# Altium schematic sheet POWER&USB.SchDoc
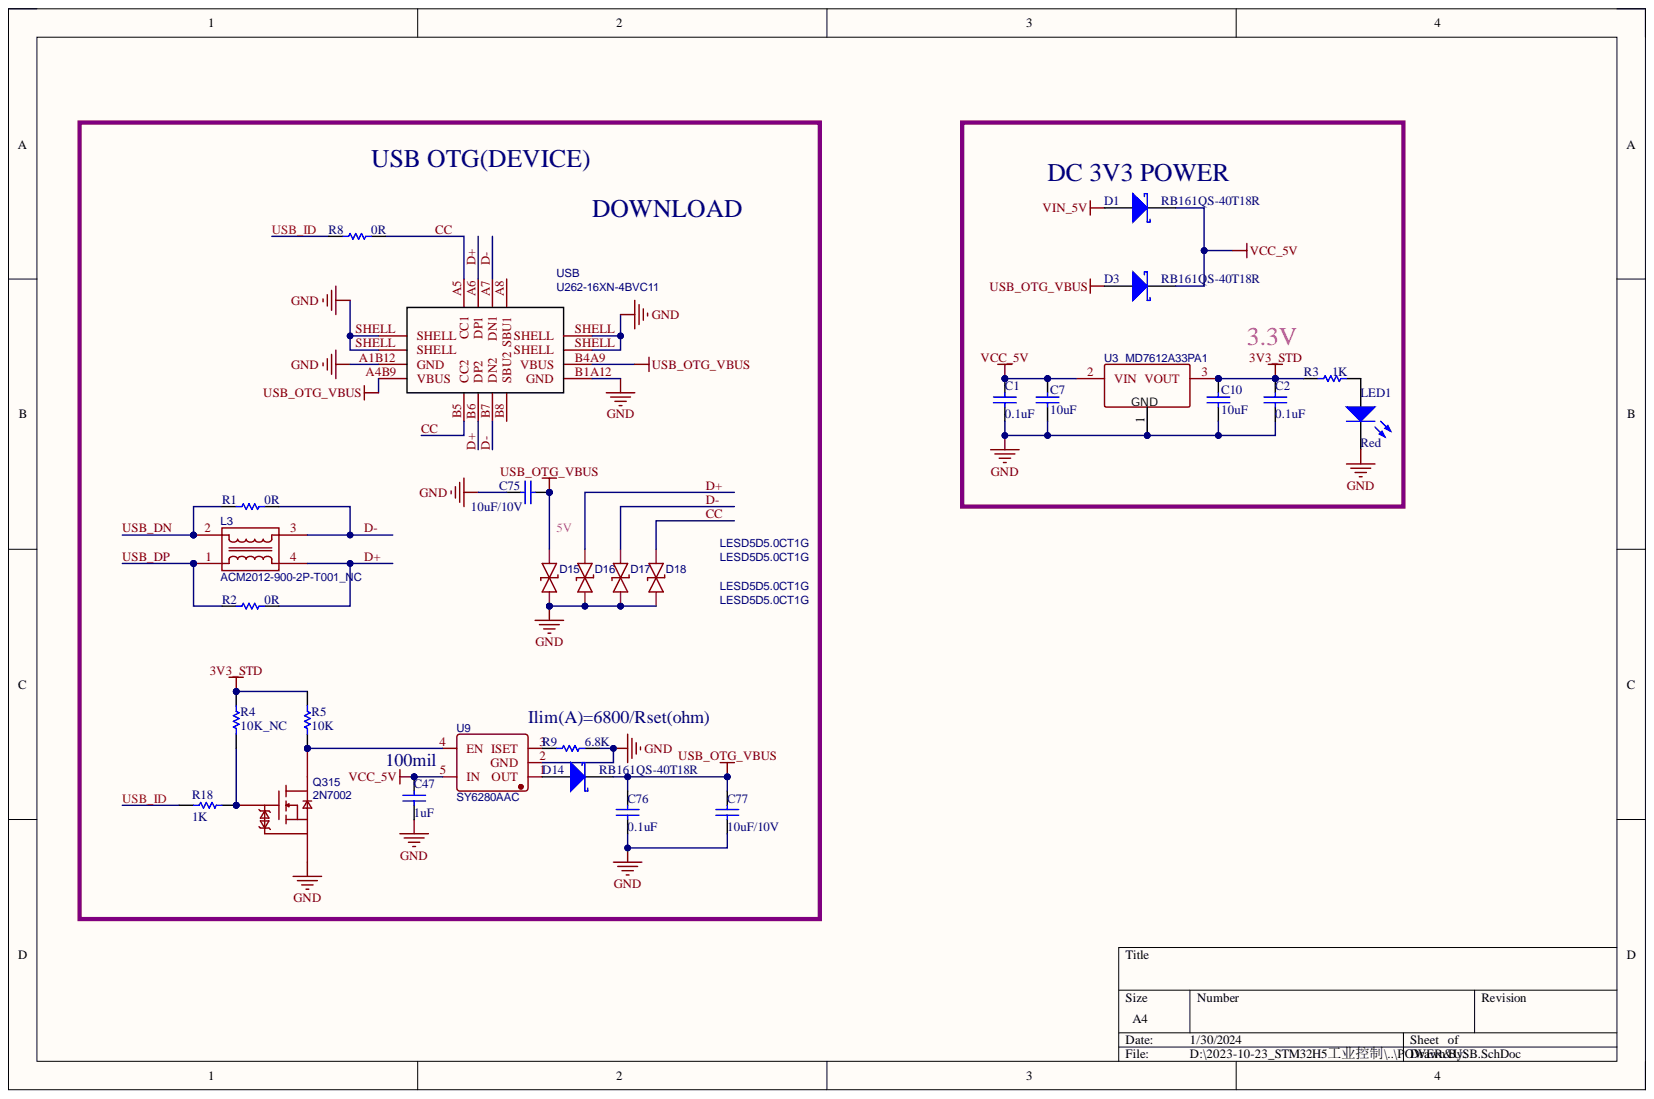
[diagram p0: POWER&USB.SchDoc]

## Parts
C1  0.1uF  [0201]
C2  0.1uF  [0201]
C7  10uF  [0402]
C10  10uF  [0402]
C47  1uF  [0201]
C75  10uF/10V  [0402]
C76  0.1uF  [0201]
C77  10uF/10V  [0402]
D1  RB161QS-40T18R  [X2-DFN1006-2_L1.0-W0.6-P0.65-RD]
D3  RB161QS-40T18R  [X2-DFN1006-2_L1.0-W0.6-P0.65-RD]
D14  RB161QS-40T18R  [X2-DFN1006-2_L1.0-W0.6-P0.65-RD]
D15  LESD5D5.0CT1G  [SOD-523_L1.2-W0.8-LS1.6-BI]
D16  LESD5D5.0CT1G  [SOD-523_L1.2-W0.8-LS1.6-BI]
D17  LESD5D5.0CT1G  [SOD-523_L1.2-W0.8-LS1.6-BI]
D18  LESD5D5.0CT1G  [SOD-523_L1.2-W0.8-LS1.6-BI]
L3  ACM2012-900-2P-T001_NC  [FILTER-SMD_4P-L2.0-W1.2-BL]
LED1  Red  [LED0402]
Q315  2N7002  [SOT-23-3_L2.9-W1.3-P1.90-LS2.4-BR]
R1  0R  [0201]
R2  0R  [0201]
R3  1K  [0201]
R4  10K_NC  [0201]
R5  10K  [0201]
R8  0R  [0201]
R9  6.8K  [0201]
R18  1K  [0201]
U3  MD7612A33PA1  [SOT-89-3_L4.5-W2.5-P1.50-LS4.2-BR]
U9  SY6280AAC  [SOT-23-5_L3.0-W1.7-P0.95-LS2.8-BR]
USB  U262-16XN-4BVC11  [TYPEC-12PIN - duplicate]

## Nets
3V3_STD: C2.1 C10.2 R3.1 R4.1 R5.1 U3.3
GND: C1.2 C2.2 C7.1 C10.1 C47.2 C75.1 C76.2 C77.1 D15.1 D16.1 D17.1 D18.1 LED1.2 Q315.2 R9.1 U3.1 U9.2(GND) USB.A1B12(GND) USB.B1A12(GND) USB.SHELL
USB_OTG_VBUS: C75.2 C76.1 C77.2 D3.1 D14.2 D15.2 USB.A4B9(VBUS) USB.B4A9(VBUS)
VCC_5V: C1.1 C7.2 C47.1 D1.2 D3.2 U3.2 U9.5(IN)
VIN_5V: D1.1
CC: D18.2 R8.1 USB.A5(CC1) USB.B5(CC2)
D+: D16.2 L3.4 R2.1 USB.A6(DP1) USB.B6(DP2)
D-: D17.2 L3.3 R1.1 USB.A7(DN1) USB.B7(DN2)
USB_DN: L3.2 R1.2
USB_DP: L3.1 R2.2
USB_ID: R8.2 R18.1
NetD14_1: D14.1 U9.1(OUT)
NetLED1_1: LED1.1 R3.2
NetQ315_1: Q315.1 R4.2 R18.2
NetQ315_3: Q315.3 R5.2 U9.4(EN)
NetR9_2: R9.2 U9.3(ISET)

Not connected: USB A8(SBU1) B8(SBU2)

## Library data of the parts
C1 C2 C47 C75-C77 = Cap: Capacitor; PartNumber: CAP100NF; Component Kind: Standard; LatestRevisionDate: 17-Jul-2002; LatestRevisionNote: Re-released for DXP Platform.; Library Name: Miscellaneous Devices.IntLib; PackageReference: 0402; Published: 8-Jun-2000; Signal Integrity: Cap; Simulation: CAP; Publisher: Altium Limited
  C1 C2 C76: Value: 0.1uF
  C47: Value: 1uF
  C75 C77: Value: 10uF/10V
C7 C10 = Cap: Component Kind: Standard; Value: 10uF; Library Name: STLINK.SCHLIB
D1 D3 D14 = D Schottky: Schottky Diode; Code_JEDEC: DO-214-AA; Component Kind: Standard; JLC NO: C2837790; LatestRevisionDate: 17-Jul-2002; LatestRevisionNote: Re-released for DXP Platform.; Library Name: Miscellaneous Devices.IntLib; PackageDescription: DO-214-AA/SMB; 2 C-Bend Leads; Body 5.3 x 3.6 mm, inc. leads (LxW); PackageReference: SMB; Published: 8-Jun-2000; Signal Integrity: D Schottky; Simulation: SKYDIODE; Value: RB161QS-40T18R; Publisher: Altium Limited
D15-D18 = LESD5D5.0CT1G: BOM_Manufacturer: Leshan Radio; Contributor: LCSC; BOM_JLCPCB Part Class: Extended Part; BOM_Manufacturer Part: LESD5D5.0CT1G; BOM_Supplier: LCSC; BOM_Supplier Part: C383211; Component Kind: Standard; spicePre: D; spiceSymbolName: LESD5D5.0CT1G
L3 = ACM2012-900-2P-T001: BOM_Manufacturer: TDK; Contributor: LCSC; BOM_JLCPCB Part Class: Extended Part; BOM_Manufacturer Part: ACM2012-900-2P-T001; BOM_Supplier: LCSC; BOM_Supplier Part: C307642; Component Kind: Standard; spicePre: L; spiceSymbolName: ACM2012-900-2P-T001
LED1 = LED2: Typical RED, GREEN, YELLOW, AMBER GaAs LED; Component Kind: Standard; LatestRevisionDate: 17-Jul-2002; LatestRevisionNote: Re-released for DXP Platform.; Library Name: Miscellaneous Devices.IntLib; PackageDescription: SM LED; 2 Flat Leads; PackageReference: 3.2X1.6X1.1; PackageVersion: Feb-2002; Published: 8-Jun-2000; Simulation: LED2; Value: Red; Publisher: Altium Limited
Q315 = 2N7002_C916396: Contributor: LCSC; BOM_JLCPCB Part Class: Extended Part; BOM_Manufacturer Part: 2N7002; BOM_Supplier: LCSC; BOM_Supplier Part: C916396; Component Kind: Standard; Library Name: HM2.SchLib; spicePre: Q; spiceSymbolName: 2N7002_C916396
R1-R5 R8 R9 R18 = Res1: Resistor; PartNumber: RES2.2K; Component Kind: Standard; LatestRevisionDate: 17-Jul-2002; LatestRevisionNote: Re-released for DXP Platform.; Library Name: Miscellaneous Devices.IntLib; PackageReference: 0402; Published: 8-Jun-2000; Signal Integrity: Res; Simulation: RESISTOR; Publisher: Altium Limited
  R1 R2 R8: Value: 0R
  R3 R18: Value: 1K
  R4: Value: 10K_NC
  R5: Value: 10K
  R9: Value: 6.8K
U3 = MD7612A33PA1: Contributor: lcsc; BOM_Manufacturer Part: MD7612A33PA1; BOM_Supplier: LCSC; BOM_Supplier Part: C2978350; Component Kind: Standard; Library Name: HM2.SchLib; link: https://atta.szlcsc.com/upload/public/pdf/source/20220309/DA883751BDA22ECB80106D5B2749DB60.pdf; spicePre: U; spiceSymbolName: MD7612A33PA1
U9 = SY6280AAC: BOM_Manufacturer: Silergy; BOM_JLCPCB Part Class: Extended Part; BOM_Manufacturer Part: SY6280AAC; BOM_Supplier: LCSC; BOM_Supplier Part: C55136; Component Kind: Standard; Library Name: HM2.SchLib; spicePre: U; spiceSymbolName: SY6280AAC
USB = U262-16XN-4BVC11: BOM_Manufacturer: XKB Enterprise; Contributor: LCSC; BOM_Manufacturer Part: U262-16XN-4BVC11; BOM_Supplier: LCSC; BOM_Supplier Part: C319148; Component Kind: Standard; Library Name: HM2.SchLib; spicePre: U; spiceSymbolName: U262-16XN-4BVC11
